# Altium schematic sheet iRat_2.1.SchDoc
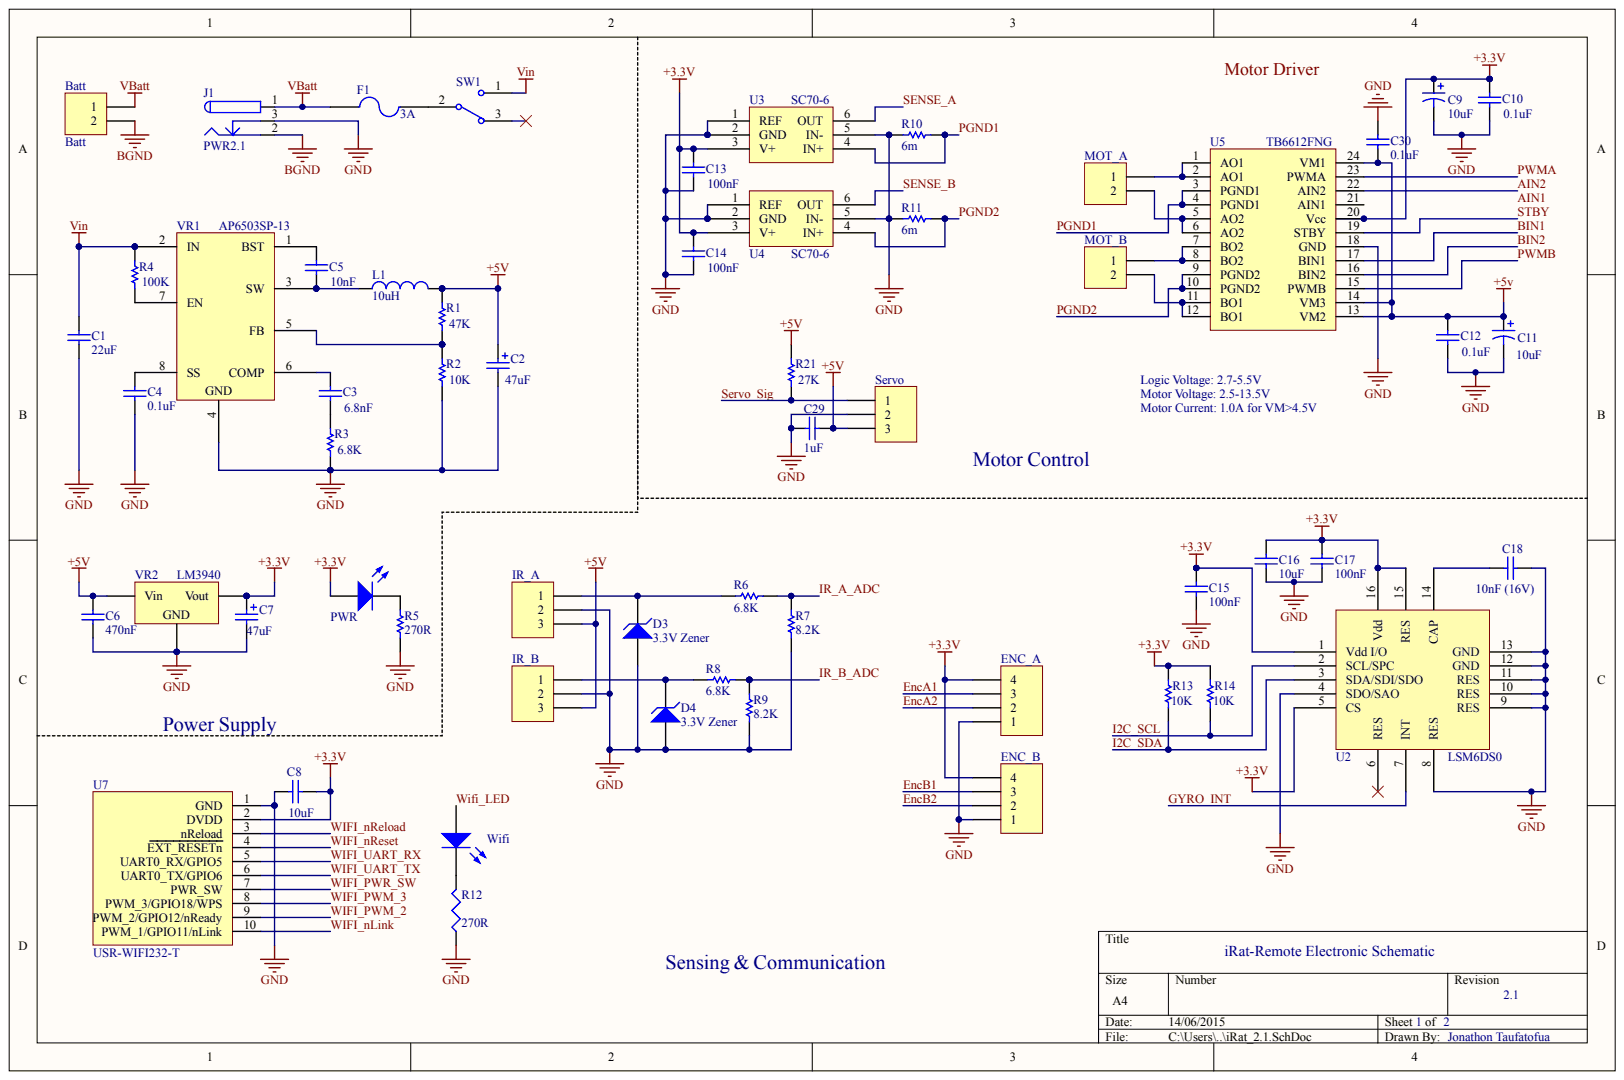
[diagram p0: iRat_2.1.SchDoc]

## Parts
Batt  Batt
C1  value 22uF  (hidden comment: Cap Semi)
C2  value 47uF  (hidden comment: Cap Pol2)
C3  value 6.8nF  (hidden comment: Cap Semi)
C4  value 0.1uF  (hidden comment: Cap Semi)
C5  value 10nF  (hidden comment: Cap Semi)
C6  value 470nF  (hidden comment: Cap Semi)
C7  value 47uF  (hidden comment: Cap Pol2)
C8  value 10uF  (hidden comment: Cap Semi)
C9  value 10uF  (hidden comment: Cap Pol3)
C10  value 0.1uF  (hidden comment: Cap Semi)
C11  value 10uF  (hidden comment: Cap Pol3)
C12  value 0.1uF  (hidden comment: Cap Semi)
C13  value 100nF  (hidden comment: Cap Semi)
C14  value 100nF  (hidden comment: Cap Semi)
C15  value 100nF  (hidden comment: Cap Semi)
C16  value 10uF  (hidden comment: Cap Semi)
C17  value 100nF  (hidden comment: Cap Semi)
C18  value 10nF (16V)  (hidden comment: Req 10nF 10%, 16V Ceramic)
C29  value 1uF  (hidden comment: Cap Semi)
C30  value 0.1uF  (hidden comment: Cap Semi)
D3  3.3V Zener
D4  3.3V Zener
ENC_A  Header 4  (value not drawn)
ENC_B  Header 4  (value not drawn)
F1  3A
IR_A  Header 3  (value not drawn)
IR_B  Header 3  (value not drawn)
J1  PWR2.1
L1  value 10uH  (hidden comment: Inductor)
MOT_A  Header 2  (value not drawn)
MOT_B  Header 2  (value not drawn)
PWR  LNJ347W83RA  (value not drawn)
R1  value 47K  (hidden comment: Res Semi)
R2  value 10K  (hidden comment: Res Semi)
R3  value 6.8K  (hidden comment: Res Semi)
R4  value 100K  (hidden comment: Res Semi)
R5  value 270R  (hidden comment: Res1)
R6  value 6.8K  (hidden comment: Res1)
R7  value 8.2K  (hidden comment: Res1)
R8  value 6.8K  (hidden comment: Res1)
R9  value 8.2K  (hidden comment: Res1)
R10  value 6m  (hidden comment: Shunt Resistor)
R11  value 6m  (hidden comment: Shunt Resistor)
R12  value 270R  (hidden comment: Res3)
R13  value 10K  (hidden comment: Res Semi)
R14  value 10K  (hidden comment: Res Semi)
R21  value 27K  (hidden comment: Res Semi)
Servo  Servo1  (value not drawn)
SW1  SW-SPDT  (value not drawn)
U2  LSM6DS0
U3  SC70-6
U4  SC70-6
U5  TB6612FNG
U7  USR-WIFI232-T
VR1  AP6503SP-13
VR2  LM3940
Wifi  LNJ347W83RA  (value not drawn)

## Nets
+3.3V: C7.1 C8.1 C9.1 C10.1 C13.2 C14.2 C15.2 C16.2 C17.2 ENC_A.4 ENC_B.4 PWR.1 R13.2 R14.2 U2.1(Vdd_I/O) U2.5(CS) U2.15(RES) U2.16(Vdd) U3.3(V+) U4.3(V+) U5.20(Vcc) U7.2(DVDD) VR2.3
+5V: C2.1 C6.2 C11.1 C12.1 C29.2 C30.1 IR_A.3 IR_B.3 L1.2 R1.2 R21.2 Servo.3 U5.13(VM2) U5.14(VM3) U5.24(VM1) VR2.1
BGND: Batt.2 J1.2
GND: C1.1 C2.2 C4.1 C6.1 C7.2 C8.2 C9.2 C10.2 C11.2 C12.2 C13.1 C14.1 C15.1 C16.1 C17.1 C18.1 C29.1 C30.2 D3.1 D4.1 ENC_A.1 ENC_B.1 IR_A.2 IR_B.2 J1.3 R2.1 R3.1 R5.1 R7.1 R9.1 R10.2 R11.1 R12.1 Servo.2 U2.4(SDO/SAO) U2.8(RES) U2.9(RES) U2.10(RES) U2.11(RES) U2.12(GND) U2.13(GND) U3.1(REF) U3.2(GND) U3.5(IN-) U4.1(REF) U4.2(GND) U4.5(IN-) U5.18(GND) U7.1(GND) VR1.4 VR2.2
VBATT: Batt.1 F1.1 J1.1
VIN: C1.2 R4.2 SW1.1 VR1.2(IN)
AIN2: U5.22(AIN2)
BIN1: U5.17(BIN1)
BIN2: U5.16(BIN2)
ENCA1: ENC_A.3
ENCA2: ENC_A.2
ENCB1: ENC_B.3
ENCB2: ENC_B.2
GYRO_INT: U2.7(INT)
I2C_SCL: R14.1 U2.2(SCL/SPC)
I2C_SDA: R13.1 U2.3(SDA/SDI/SDO)
IR_A_ADC: R6.1 R7.2
IR_B_ADC: R8.1 R9.2
PGND1: R10.1 U3.4(IN+) U5.3(PGND1) U5.4(PGND1)
PGND2: R11.2 U4.4(IN+) U5.9(PGND2) U5.10(PGND2)
PWMA: U5.23(PWMA)
PWMB: U5.15(PWMB)
SENSE_A: U3.6(OUT)
SENSE_B: U4.6(OUT)
SERVO_SIG: R21.1 Servo.1
STBY: U5.19(STBY)
WIFI_LED: Wifi.1
WIFI_NLINK: U7.10(PWM_1/GPIO11/nLink)
WIFI_NRELOAD: U7.3(nReload)
WIFI_NRESET: U7.4(EXT_RESETn)
WIFI_PWM_2: U7.9(PWM_2/GPIO12/nReady)
WIFI_PWM_3: U7.8(PWM_3/GPIO18/WPS)
WIFI_PWR_SW: U7.7(PWR_SW)
WIFI_UART_RX: U7.5(UART0_RX/GPIO5)
WIFI_UART_TX: U7.6(UART0_TX/GPIO6)
NetC3_1: C3.1 R3.2
NetC3_2: C3.2 VR1.6(COMP)
NetC4_2: C4.2 VR1.8(SS)
NetC5_1: C5.1 L1.1 VR1.3(SW)
NetC5_2: C5.2 VR1.1(BST)
NetC18_2: C18.2 U2.14(CAP)
NetD3_2: D3.2 IR_A.1 R6.2
NetD4_2: D4.2 IR_B.1 R8.2
NetF1_2: F1.2 SW1.2
NetMOT_A_1: MOT_A.1 U5.1(AO1) U5.2(AO1)
NetMOT_A_2: MOT_A.2 U5.5(AO2) U5.6(AO2)
NetMOT_B_1: MOT_B.1 U5.7(BO2) U5.8(BO2)
NetMOT_B_2: MOT_B.2 U5.11(BO1) U5.12(BO1)
NetPWR_2: PWR.2 R5.2
NetR1_1: R1.1 R2.2 VR1.5(FB)
NetR4_1: R4.1 VR1.7(EN)
NetR12_2: R12.2 Wifi.2
AIN1:

Not connected: SW1 3; U2 6(RES); U5 21(AIN1)

## Library data of the parts
C7 = (no symbol name): Note: Needs at least 33uF for stability
L1 = (no symbol name): Code_IEC: 1005; Published: 8-Jun-2000; LatestRevisionDate: 29-May-2009; LatestRevisionNote: IPC-7351 Footprint Added.; PackageReference: 0402-A; PackageDescription: Chip Inductor; Code_JEITA: 0402; Publisher: Altium Limited
Batt C1-C6 C8-C18 C29 C30 D3 D4 ENC_A ENC_B F1 IR_A IR_B J1 MOT_A MOT_B PWR R1-R14 R21 Servo SW1 U2-U5 U7 VR1 VR2 Wifi = (no symbol name)
  Batt ENC_A ENC_B IR_A IR_B MOT_A MOT_B Servo: LatestRevisionDate: 17-Jul-2002; LatestRevisionNote: Re-released for DXP Platform.
  C1 C3-C6 C8 C10 C12-C18 C29 C30: Published: 8-Jun-2000; LatestRevisionDate: 29-May-2009; LatestRevisionNote: IPC-7351 Footprint Added.; PackageReference: C1206; PackageDescription: Chip Capacitor
  C2: Published: 8-Jun-2000; LatestRevisionDate: 17-Jul-2002; LatestRevisionNote: Re-released for DXP Platform.; PackageReference: POLAR0.8; PackageDescription: Polarized Capacitor; 2 Leads
  C9 C11: Published: 8-Jun-2000; LatestRevisionDate: 29-May-2009; LatestRevisionNote: IPC-7351 Footprint Added.; PackageReference: C0805; PackageDescription: Chip Capacitor
  D3 D4: Published: 8-Jun-2000; LatestRevisionDate: 17-Jul-2002; LatestRevisionNote: Re-released for DXP Platform.; PackageReference: DIODE-0.7; PackageDescription: Diode, Thru-Hole; 2 Leads; 0.7 in Pin Spacing
  F1: Published: 8-Jun-2000; LatestRevisionDate: 17-Jul-2002; LatestRevisionNote: Re-released for DXP Platform.; PackageReference: PIN-W2/E2.8; PackageDescription: Thru-Hole; 2 Leads
  J1: Published: 17-Dec-2003; DatasheetDocument: 09-May-2002; ComponentLink1Description: Manufacturer Link; ComponentLink1URL: http://domino.kycon.com/internet/Products.html
  PWR Wifi: Published: 8-Jun-2000; LatestRevisionDate: 17-Jul-2002; LatestRevisionNote: Re-released for DXP Platform.; PackageVersion: Feb-2002; PackageReference: 3.2X1.6X1.1; PackageDescription: SM LED; 2 Flat Leads
  R1-R4 R13 R14 R21: Published: 8-Jun-2000; LatestRevisionDate: 17-Jul-2002; LatestRevisionNote: Re-released for DXP Platform.; PackageReference: AXIAL-0.5; PackageDescription: Axial Device, Thru-Hole; 2 Leads; 0.5 in Pin Spacing
  R5-R11: Published: 8-Jun-2000; LatestRevisionDate: 17-Jul-2002; LatestRevisionNote: Re-released for DXP Platform.; PackageReference: AXIAL-0.3; PackageDescription: Axial Device, Thru-Hole; 2 Leads; 0.3 in Pin Spacing
  R12: Published: 8-Jun-2000; LatestRevisionDate: 29-May-2009; LatestRevisionNote: IPC-7351 Footprint Added.; PackageReference: J1-0603; PackageDescription: Chip Resistor
  SW1: Published: 24-Mar-1999; LatestRevisionDate: 17-Dec-2003; LatestRevisionNote: Schematic revised, PCB Footprint replaced.; DatasheetVersion: 05-Dec-2000; PackageReference: TL36WW15050; PackageDescription: Switch; 4 Leads; ComponentLink1Description: Manufacturer Link; ComponentLink1URL: http://www.apem.com
  VR2: Published: 24-Mar-1999; LatestRevisionDate: 29-May-2009; LatestRevisionNote: IPC-7351 Footprint Added.; PackageReference: D2PAK; PackageDescription: 3-Pin Transistor Outline Package 2.54 mm Pitch, 15.1 mm Lead Span
  Batt C1-C6 C8-C18 C29 C30 D3 D4 ENC_A ENC_B F1 IR_A IR_B J1 MOT_A MOT_B PWR R1-R14 R21 Servo SW1 VR2 Wifi: Publisher: Altium Limited
